# Altium schematic sheet EntradaCPDLB50.SchDoc
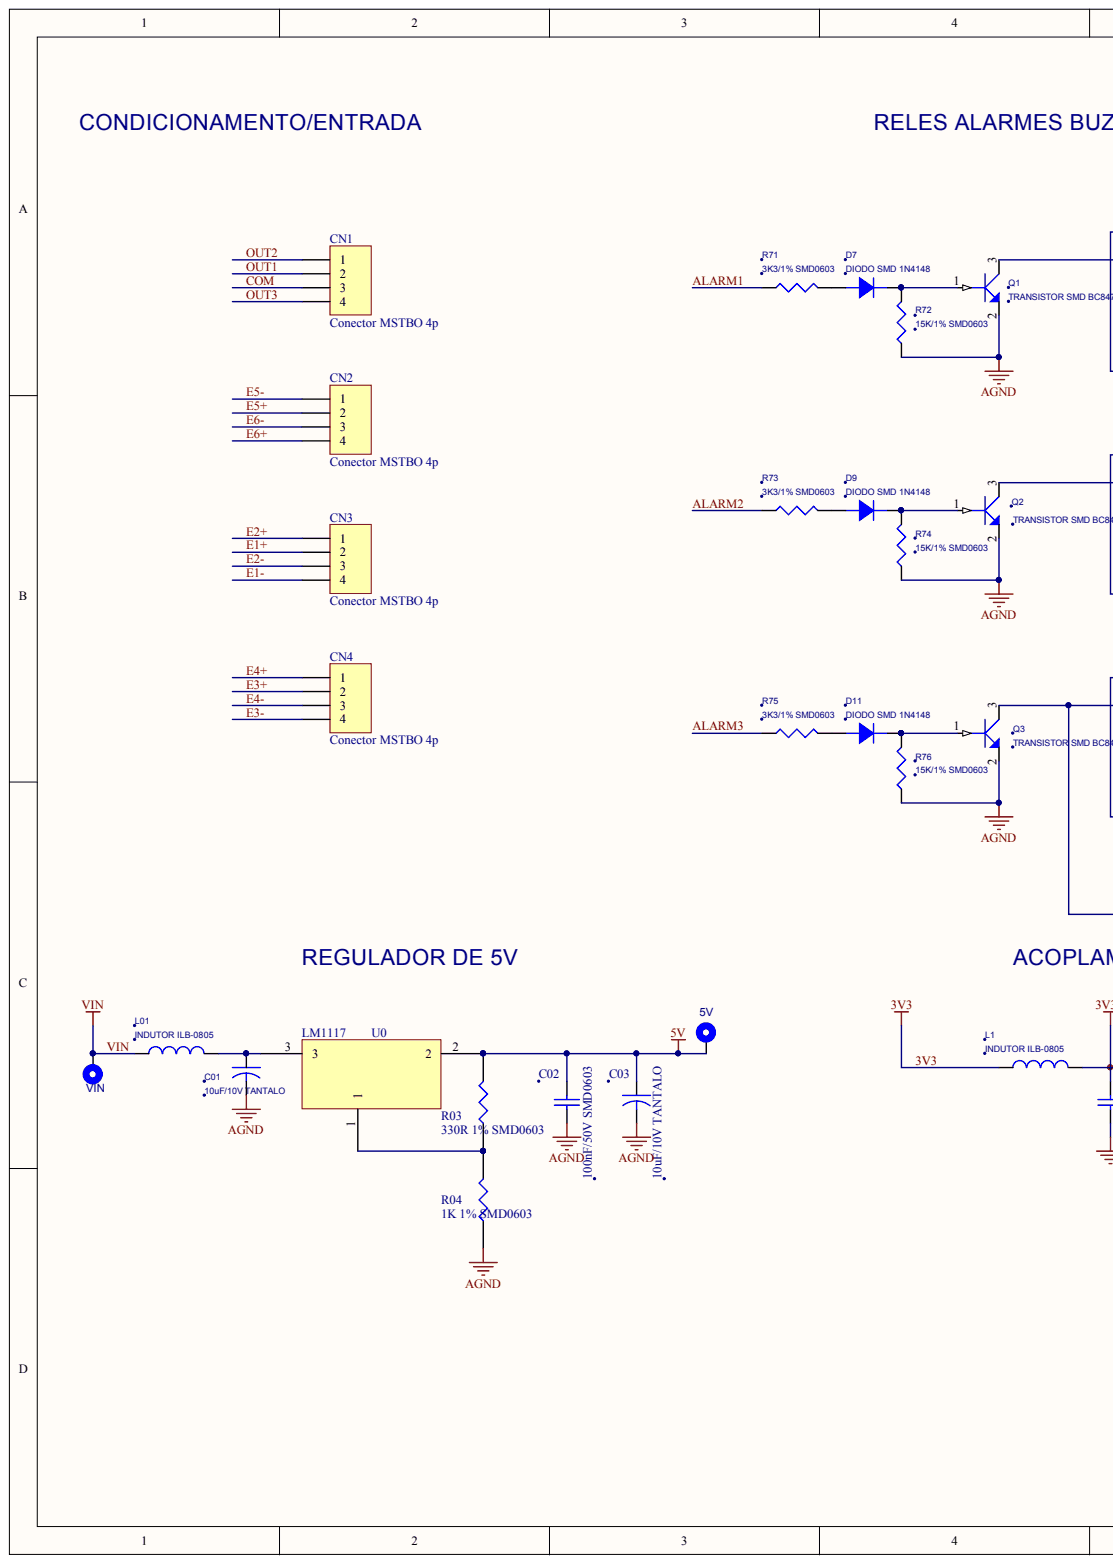
[diagram p0: EntradaCPDLB50.SchDoc, left]
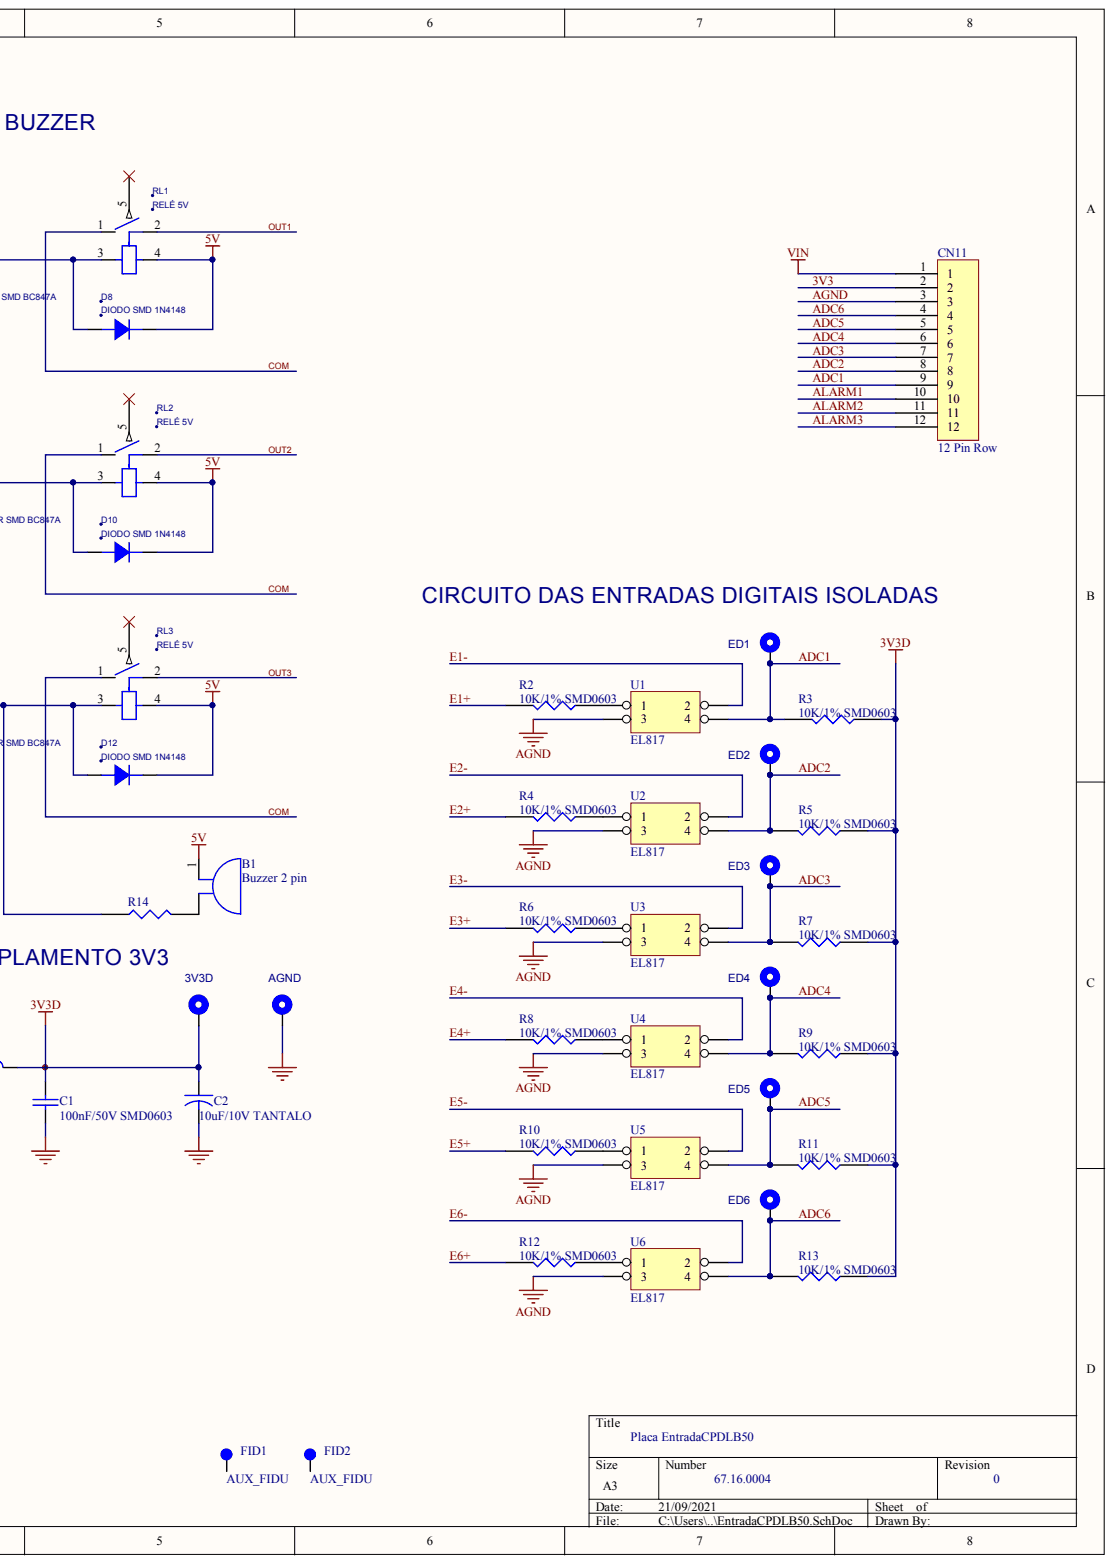
[diagram p1: EntradaCPDLB50.SchDoc, right]

## Parts
3V3D  3V3D  [PTR31]
5V  5V  [PTR31]
AGND  GND  [PTR31]  (value not drawn)
B1  Buzzer 2 pin  [Buzzer 5V d20mm]
C01  10uF/10V TANTALO  [TANA]
C1  100nF/50V SMD0603  [0603]
C02  100nF/50V SMD0603  [0603]
C2  10uF/10V TANTALO  [TANA]
C03  10uF/10V TANTALO  [TANA]
CN1  Conector MSTBO 4p  [MSTBO-DIR]
CN2  Conector MSTBO 4p  [MSTBO-DIR]
CN3  Conector MSTBO 4p  [MSTBO-ESQ]
CN4  Conector MSTBO 4p  [MSTBO-ESQ]
CN11  12 Pin Row  [12 Pin Row]
D7  DIODO SMD 1N4148  [DIODOSMD]
D8  DIODO SMD 1N4148  [DIODOSMD]
D9  DIODO SMD 1N4148  [DIODOSMD]
D10  DIODO SMD 1N4148  [DIODOSMD]
D11  DIODO SMD 1N4148  [DIODOSMD]
D12  DIODO SMD 1N4148  [DIODOSMD]
ED1  (no value)  [PTSMD60]
ED2  (no value)  [PTSMD60]
ED3  (no value)  [PTSMD60]
ED4  (no value)  [PTSMD60]
ED5  (no value)  [PTSMD60]
ED6  (no value)  [PTSMD60]
FID1  AUX_FIDU  [AUX_FIDUCIAL]
FID2  AUX_FIDU  [AUX_FIDUCIAL]
L01  INDUTOR ILB-0805  [BLM21]
L1  INDUTOR ILB-0805  [BLM21]
Q1  TRANSISTOR SMD BC847A  [BC847A]
Q2  TRANSISTOR SMD BC847A  [bc847a]
Q3  TRANSISTOR SMD BC847A  [bc847a]
R2  10K/1% SMD0603  [0603]
R03  330R 1% SMD0603  [0603]
R3  10K/1% SMD0603  [0603]
R04  1K 1% SMD0603  [0603]
R4  10K/1% SMD0603  [0603]
R5  10K/1% SMD0603  [0603]
R6  10K/1% SMD0603  [0603]
R7  10K/1% SMD0603  [0603]
R8  10K/1% SMD0603  [0603]
R9  10K/1% SMD0603  [0603]
R10  10K/1% SMD0603  [0603]
R11  10K/1% SMD0603  [0603]
R12  10K/1% SMD0603  [0603]
R13  10K/1% SMD0603  [0603]
R14  (no value)  [0603]
R71  3K3/1% SMD0603  [C0603]
R72  15K/1% SMD0603  [C0603]
R73  3K3/1% SMD0603  [C0603]
R74  15K/1% SMD0603  [C0603]
R75  3K3/1% SMD0603  [C0603]
R76  15K/1% SMD0603  [C0603]
RL1  RELÉ 5V  [RELE2]
RL2  RELÉ 5V  [RELE2]
RL3  RELÉ 5V  [RELE2]
U0  LM1117  [SOT223G]
U1  EL817  [DIP4]
U2  EL817  [DIP4]
U3  EL817  [DIP4]
U4  EL817  [DIP4]
U5  EL817  [DIP4]
U6  EL817  [DIP4]
VIN  VIN  [PTR31]

## Nets
3V3D: 3V3D.1 C1.1 C2.1 L1.2 R3.1 R5.1 R7.1 R9.1 R11.1 R13.1
5V: 5V.1 B1.1 C02.1 C03.1 D8.2 D10.2 D12.2 R03.2 RL1.4 RL2.4 RL3.4 U0.2
3V3: CN11.2 L1.1
ADC1: CN11.9 ED1.1 R3.2 U1.4
ADC2: CN11.8 ED2.1 R5.2 U2.4
ADC3: CN11.7 ED3.1 R7.2 U3.4
ADC4: CN11.6 ED4.1 R9.2 U4.4
ADC5: CN11.5 ED5.1 R11.2 U5.4
ADC6: CN11.4 ED6.1 R13.2 U6.4
AGND: AGND.1 C1.2 C01.2 C2.2 C02.2 C03.2 CN11.3 Q1.2 Q2.2 Q3.2 R04.1 R72.1 R74.1 R76.1 U1.3 U2.3 U3.3 U4.3 U5.3 U6.3
ALARM1: CN11.10 R71.1
ALARM2: CN11.11 R73.1
ALARM3: CN11.12 R75.1
COM: CN1.3 RL1.1 RL2.1 RL3.1
E1+: CN3.2 R2.2
E1-: CN3.4 U1.2
E2+: CN3.1 R4.2
E2-: CN3.3 U2.2
E3+: CN4.2 R6.2
E3-: CN4.4 U3.2
E4+: CN4.1 R8.2
E4-: CN4.3 U4.2
E5+: CN2.2 R10.2
E5-: CN2.1 U5.2
E6+: CN2.4 R12.2
E6-: CN2.3 U6.2
OUT1: CN1.2 RL1.2
OUT2: CN1.1 RL2.2
OUT3: CN1.4 RL3.2
VIN: CN11.1 L01.1 VIN.1
NetB1_2: B1.2 R14.2
NetC01_1: C01.1 L01.2 U0.3
NetD7_1: D7.1 R71.2
NetD7_2: D7.2 Q1.1 R72.2
NetD8_1: D8.1 Q1.3 RL1.3
NetD9_1: D9.1 R73.2
NetD9_2: D9.2 Q2.1 R74.2
NetD10_1: D10.1 Q2.3 RL2.3
NetD11_1: D11.1 R75.2
NetD11_2: D11.2 Q3.1 R76.2
NetD12_1: D12.1 Q3.3 R14.1 RL3.3
NetR2_1: R2.1 U1.1
NetR03_1: R03.1 R04.2 U0.1
NetR4_1: R4.1 U2.1
NetR6_1: R6.1 U3.1
NetR8_1: R8.1 U4.1
NetR10_1: R10.1 U5.1
NetR12_1: R12.1 U6.1

Not connected: FID1 1; FID2 1; RL1 5; RL2 5; RL3 5

## Library data of the parts
3V3D 5V AGND ED1-ED6 VIN = AUX_PT: PONTO DE TESTE SMD; Component Kind: Standard; Library Name: AUXILIAR_SYM.LIB
C01 = C: Component Kind: Standard
C1 C02 = CAP: Capacitor; Component Kind: Standard
C2 C03 = CAPACITOR: Component Kind: Standard; Description: Capacitor
  C03: : SMD 1206
D7 = DIODE: Component Kind: Standard
D8 = 1N4148_3: Component Kind: Standard
D9-D12 = DIODE_Dup1: Component Kind: Standard
FID1 FID2 = AUX_FIDU: FIDUCIAL; Component Kind: Standard; Library Name: AUXILIAR_SYM.LIB
L01 L1 = INDUCTOR: Component Kind: Standard
Q1-Q3 = BC847AL: Component Kind: Standard
R2 R03 R3 R04 R4-R14 = RES1: Component Kind: Standard
R71 = R: Component Kind: Standard
R72 = R_Dup2: Component Kind: Standard
R73-R76 = R_Dup1: Component Kind: Standard
RL1-RL3 = REL_0: Component Kind: Standard
U0 = LM 2574 - 5V: Text Field1: 100; Text Field2: 50; Component Kind: Standard
U1-U6 = HEADER 2X2: Component Kind: Standard
B1 = Buzzer 2 pin: Component Kind: Standard; Library Name: SCH - Buzzer 2 pin.SCHLIB
CN1-CN4 = Conector MSTBO 4p: Component Kind: Standard; Library Name: md30e-1.SCHLIB
CN11 = 12 Pin Row: Component Kind: Standard; Library Name: SCH - CONNECTORS - 12 PIN.SchLib
